# Altium schematic sheet [09] HDMI.SchDoc("[09] HDMI")
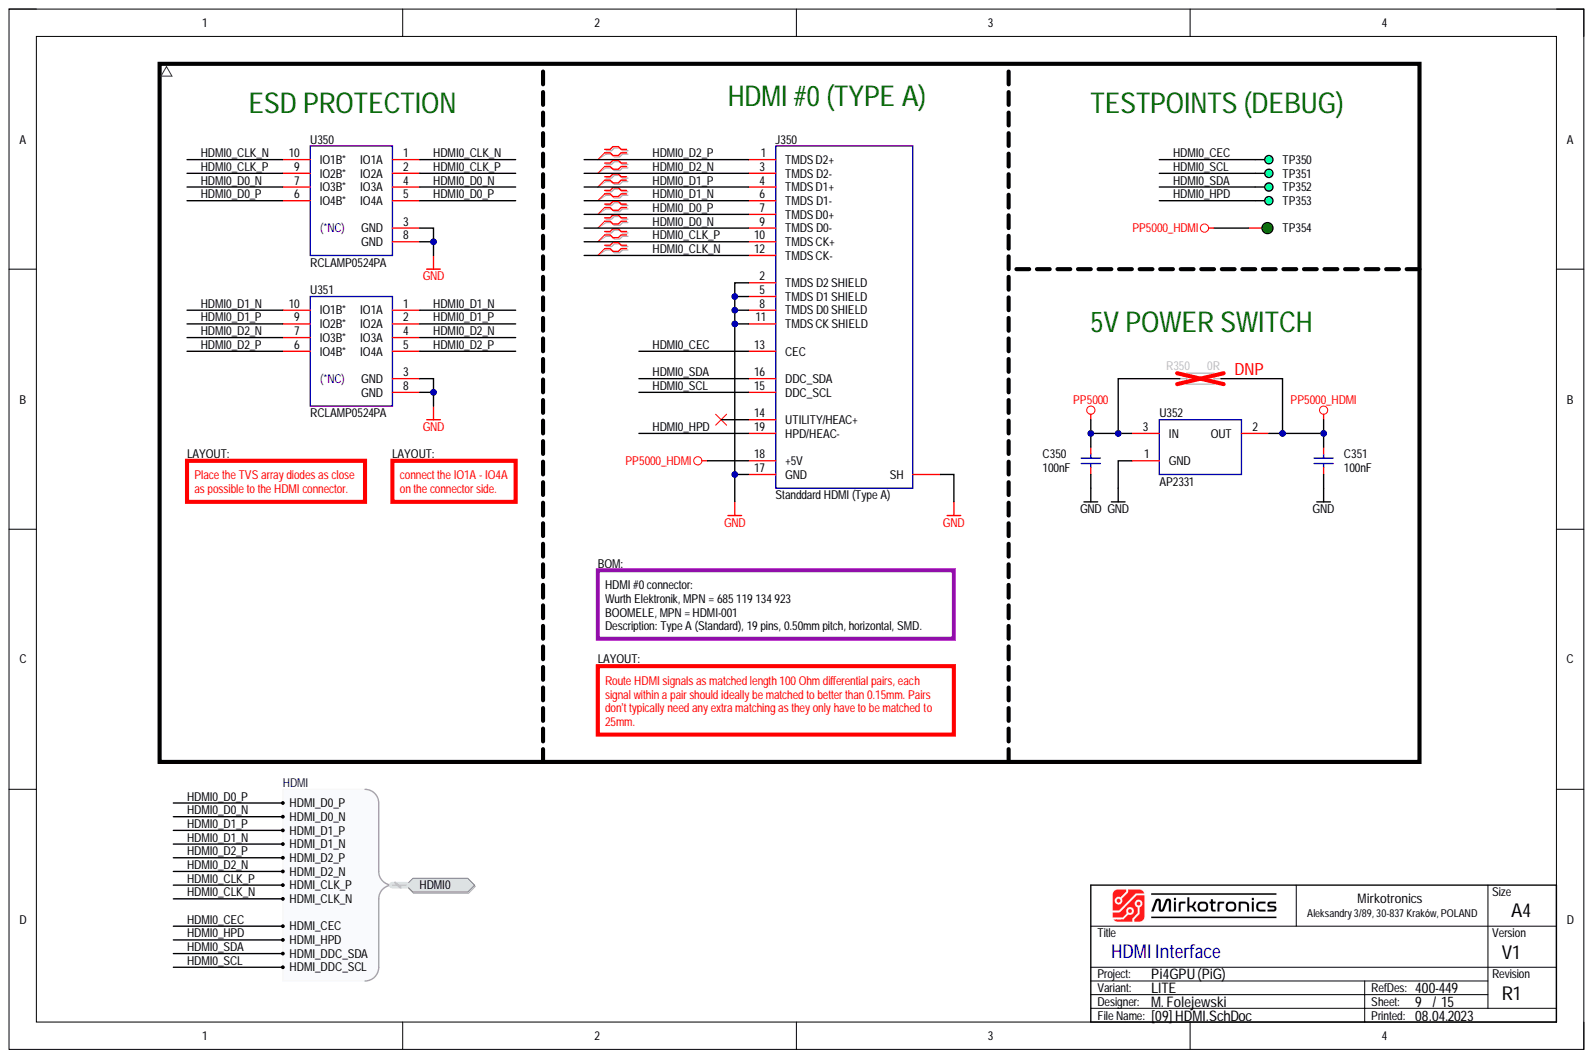
[diagram p0: [09] HDMI.SchDoc]

## Parts
C350  100nF  [CAP_0603_R]
C351  100nF  [CAP_0603_R]
J350  Standdard HDMI (Type A)  Component Subtype: HDMI (Type A)  [CON_HDMI_STD]
R350  0R  [RES_0603_R]
TP350  DEBUG  [PCB_TP_DBG_50]  (value not drawn)  (no BOM)
TP351  DEBUG  [PCB_TP_DBG_50]  (value not drawn)  (no BOM)
TP352  DEBUG  [PCB_TP_DBG_50]  (value not drawn)  (no BOM)
TP353  DEBUG  [PCB_TP_DBG_50]  (value not drawn)  (no BOM)
TP354  ICT  [PCB_TP_ICT_100]  (value not drawn)  (no BOM)
U350  RCLAMP0524PA  [ICC_SLP2510P8_250X100X60_P50_R]
U351  RCLAMP0524PA  [ICC_SLP2510P8_250X100X60_P50_R]
U352  AP2331  [PKG_SOT23-3L_SC59_P190_R]

## Ports
HDMI0, HDMI0.HDMI_CEC, HDMI0.HDMI_CLK_N, HDMI0.HDMI_CLK_P, HDMI0.HDMI_D0_N, HDMI0.HDMI_D0_P, HDMI0.HDMI_D1_N, HDMI0.HDMI_D1_P, HDMI0.HDMI_D2_N, HDMI0.HDMI_D2_P, HDMI0.HDMI_DDC_SCL, HDMI0.HDMI_DDC_SDA, HDMI0.HDMI_HPD

## Nets
GND: C350.1 C351.1 J350.2(TMDS_D2_SHIELD) J350.5(TMDS_D1_SHIELD) J350.8(TMDS_D0_SHIELD) J350.11(TMDS_CK_SHIELD) J350.17(GND) J350.SH U350.3(GND) U350.8(GND) U351.3(GND) U351.8(GND) U352.1
PP5000: C350.2 R350.2 U352.3
PP5000_HDMI: C351.2 J350.18(+5V) R350.1 TP354.1 U352.2
HDMI0_CEC: J350.13(CEC) TP350.1 ; port HDMI0
HDMI0_CLK_N: J350.12(TMDS_CK-) U350.1(IO1A) U350.10(IO1B*) ; port HDMI0
HDMI0_CLK_P: J350.10(TMDS_CK+) U350.2(IO2A) U350.9(IO2B*) ; port HDMI0
HDMI0_D0_N: J350.9(TMDS_D0-) U350.4(IO3A) U350.7(IO3B*) ; port HDMI0
HDMI0_D0_P: J350.7(TMDS_D0+) U350.5(IO4A) U350.6(IO4B*) ; port HDMI0
HDMI0_D1_N: J350.6(TMDS_D1-) U351.1(IO1A) U351.10(IO1B*) ; port HDMI0
HDMI0_D1_P: J350.4(TMDS_D1+) U351.2(IO2A) U351.9(IO2B*) ; port HDMI0
HDMI0_D2_N: J350.3(TMDS_D2-) U351.4(IO3A) U351.7(IO3B*) ; port HDMI0
HDMI0_D2_P: J350.1(TMDS_D2+) U351.5(IO4A) U351.6(IO4B*) ; port HDMI0
HDMI0_HPD: J350.19(HPD/HEAC-) TP353.1 ; port HDMI0
HDMI0_SCL: J350.15(DDC_SCL) TP351.1 ; port HDMI0
HDMI0_SDA: J350.16(DDC_SDA) TP352.1 ; port HDMI0

Not connected: J350 14(UTILITY/HEAC+)

## Library data of the parts
C350 C351 = CAP-0008: Capacitor; MLCC; 100nF; TBD; TBD; TBD; TBD; SMD; 0603 (1608);; Database Table Name: CAPACITOR$; Component Kind: Standard; Component Subtype: MLCC; Component Type: Capacitor; Manufacturer: TBD; Mounting Type: SMD; MPN: TBD; Package (Case): 0603 (1608); Supplier: ?; Value: 100nF; Library Name: MirFol_db_local.DbLib
J350 = CON_HDMI_1.4+_A2: Connector; HDMI v1.4+;; Manufacturer: BOOMELE; MPN: HDMI-001; Package (Case): SMD; Component Kind: Standard; Component Type: Connector; Mounting Type: SMD; Supplier: ?; Value: Standdard HDMI (Type A); Library Name: MirFol_lib.SchLib
R350 = RES-0054: Resistor; Thick Film; GENERIC; TBD; TBD; TBD; TBD; TBD; SMD; 0603 (1608);; Database Table Name: RESISTOR$; Component Kind: Standard; Component Subtype: Thick Film; Component Type: Resistor; Manufacturer: GENERIC; Mounting Type: SMD; MPN: GENERIC; Package (Case): 0603 (1608); Supplier: ?; Value: 0R; Library Name: MirFol_db_local.DbLib
TP350-TP353 = PCB_TP_DBG: PCB Marking; Test Point (Debug);; Component Subtype: -; Component Type: Testpoint; Mounting Type: -; Supplier: ?; Value: DEBUG; Library Name: MirFol_lib.SchLib
TP354 = PCB_TP_ICT: Test Point (ICT); Specification: Testpoint (for ICT), SMD pad; Component Type: Testpoint (for ICT); Manufacturer: -; Mounting Type: Non component; MPN: -; Value: ICT; Library Name: MirFol_lib.SchLib
U350 U351 = ICC-0005: IC; TVS Arrays; ESD Protection; 4-Channel; 17kV Air; 12kV Contact; 0.3pF Capitance; TBD; SMD; TBD;; Manufacturer: Semtech Corporation; MPN: RCLAMP0524PATCT; Database Table Name: IC (MISC)$; Component Kind: Standard; Component Subtype: TVS Arrays; Component Type: IC; Mounting Type: SMD; Package (Case): TBD; Supplier: ?; Value: RCLAMP0524PA; Library Name: MirFol_db_local.DbLib
U352 = ICC-0008: IC; Power Switcher; TBD TBD; SMD; SC59;; Manufacturer: Diodes Incorporated; MPN: AP2331W-7; Database Table Name: IC (MISC)$; Component Kind: Standard; Component Subtype: Power Switcher; Component Type: IC; Mounting Type: SMD; Package (Case): SC59; Supplier: ?; Value: AP2331; Library Name: MirFol_db_local.DbLib
